# Altium schematic sheet pca20054_sheet5_connectors.SchDoc
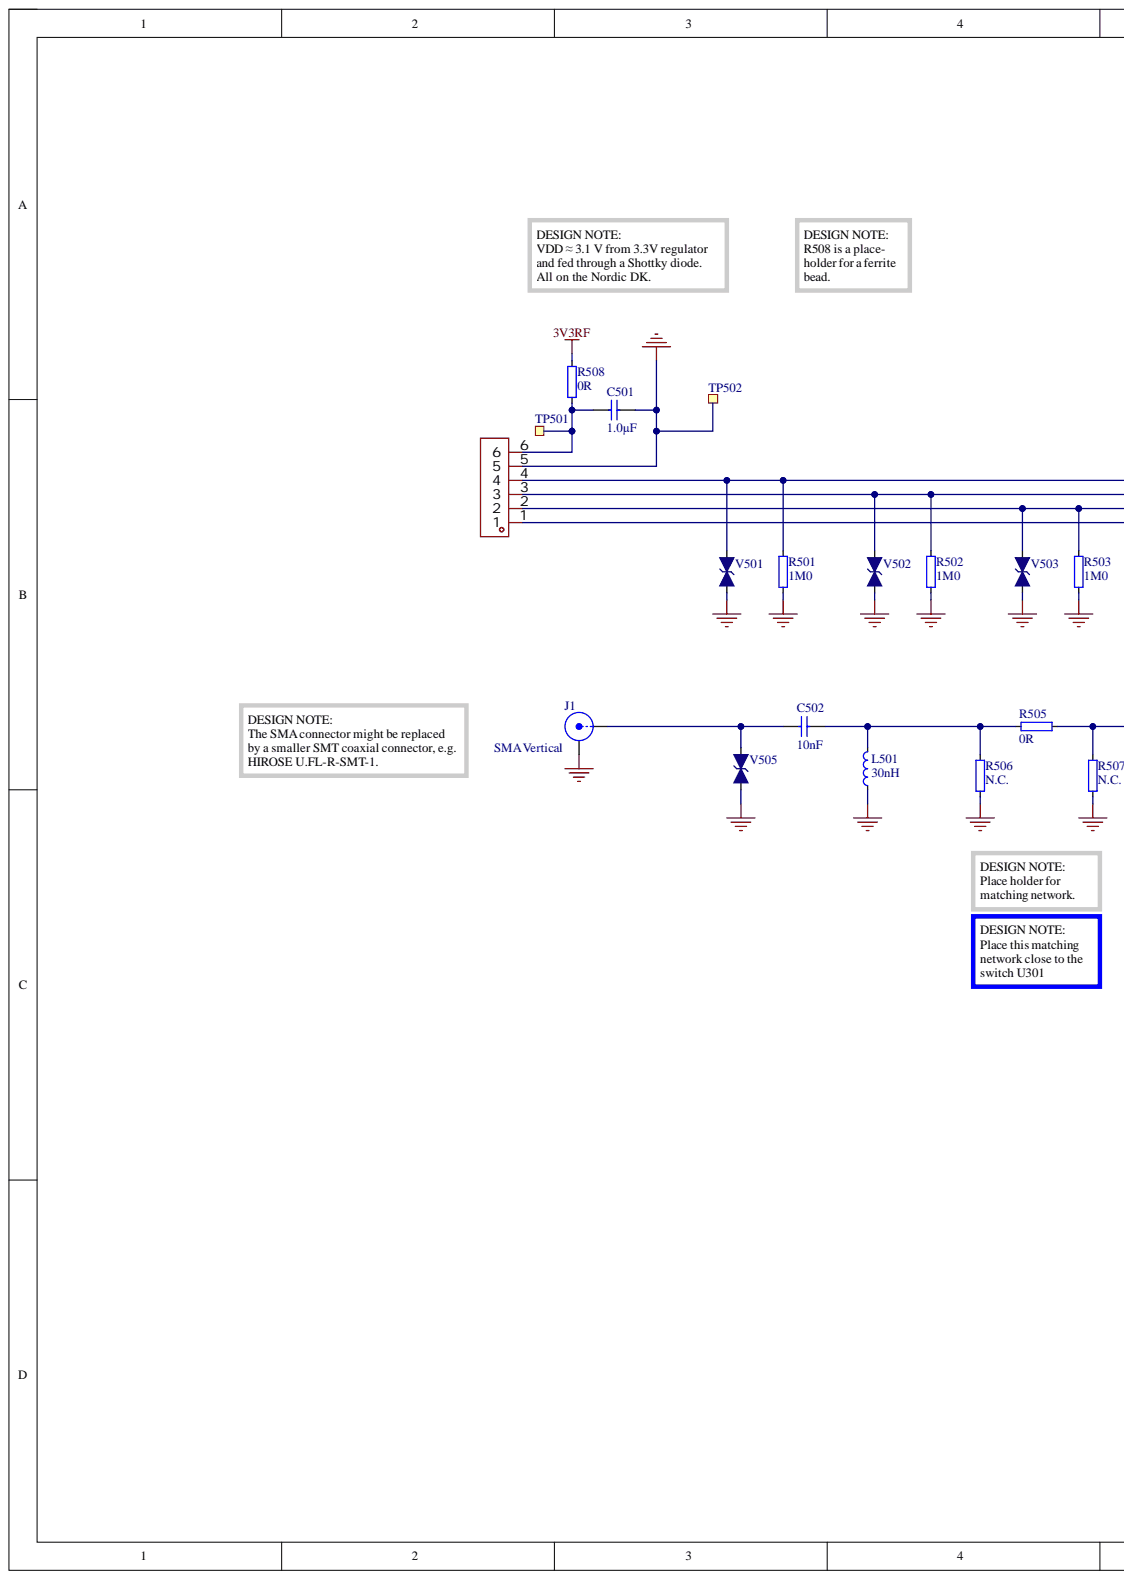
[diagram p0: pca20054_sheet5_connectors.SchDoc, left]
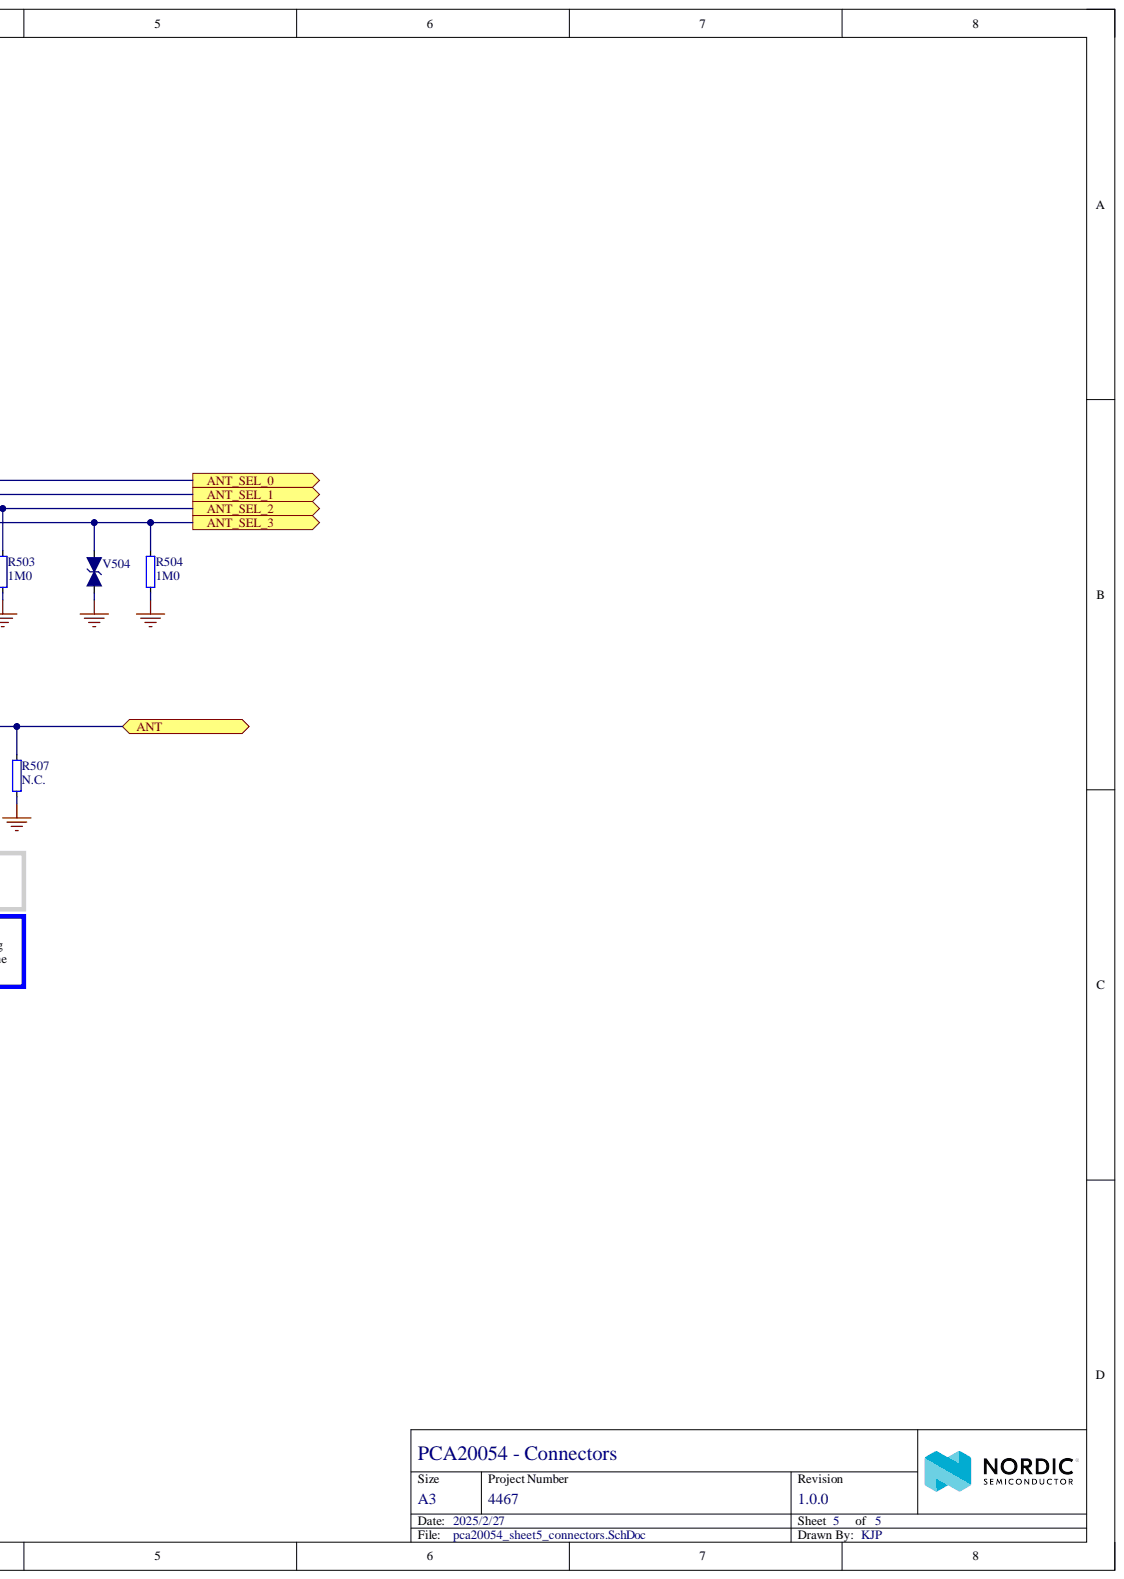
[diagram p1: pca20054_sheet5_connectors.SchDoc, right]

## Parts
C501  1.0µF  [CAPC1608X06L]
C502  10nF  [CAPC1005X04L]
J1  SMA Vertical  [CON_SMA_COAX_FEM_STRAIGHT_5P_1]
L501  30nH  [INDC1005X04L_WW]
R501  1M0  [RESC1005X04L]
R502  1M0  [RESC1005X04L]
R503  1M0  [RESC1005X04L]
R504  1M0  [RESC1005X04L]
R505  0R  [RESC1005X04L]
R506  N.C.  [RESC1005X04L_NC]
R507  N.C.  [RESC1005X04L_NC]
R508  0R  [RESC1005X04L]
TP501  Ø1.0mm,SMD  [TP_SMD_?.0mm]  (value not drawn)  (no BOM)
TP502  Ø1.0mm,SMD  [TP_SMD_?.0mm]  (value not drawn)  (no BOM)
U??  ZX-XH2.54-6PZZ  [CONN-TH_6P-P2.50_ZX-XH2.54-6PZZ]  (value not drawn)
V501  LXES15AAA1-133  [RESC1005X04L]  (value not drawn)
V502  LXES15AAA1-133  [RESC1005X04L]  (value not drawn)
V503  LXES15AAA1-133  [RESC1005X04L]  (value not drawn)
V504  LXES15AAA1-133  [RESC1005X04L]  (value not drawn)
V505  LXES15AAA1-133  [RESC1005X04L]  (value not drawn)

## Ports
ANT, ANT_SEL_0, ANT_SEL_1, ANT_SEL_2, ANT_SEL_3

## Nets
3V3RF: R508.2
GND: C501.1 J1.2 L501.1 R501.1 R502.1 R503.1 R504.1 R506.1 R507.1 TP502.1 U??.5 V501.2 V502.2 V503.2 V504.2 V505.2
ANT: R505.2 R507.2 ; port ANT
ANT_SEL_0: R501.2 U??.4 V501.1 ; port ANT_SEL_0
ANT_SEL_1: R502.2 U??.3 V502.1 ; port ANT_SEL_1
ANT_SEL_2: R503.2 U??.2 V503.1 ; port ANT_SEL_2
ANT_SEL_3: R504.2 U??.1 V504.1 ; port ANT_SEL_3
NetC501_2: C501.2 R508.1 TP501.1 U??.6
NetC502_1: C502.1 L501.2 R505.1 R506.2
NetC502_2: C502.2 J1.1 V505.1

## Library data of the parts
C501 = C0603-1µ0: Capacitor, X7R, ±10%; Part number IPS: 00000000616; Artikkelnr Noca: 20039282; Database Table Name: Capacitors$; Note: 16V; Component Kind: Standard; ComponentLink1Description: Datasheet; ComponentLink2Description: Supplier link; Footprint Doc: 0603; LatestRevisionDate: 2013-06-05; Library Name: Nordic Passive DB.DbLib; Part Number: C0603-1µ0; Pin Count: 2; Published: 2009-05-08; Value: 1.0µF; Publisher: Nordic Semiconductor
C502 = C0402-10n: Capacitor, X7R, ±10%; Part number IPS: 00000007784; Artikkelnr Noca: 20039166; Database Table Name: Capacitors$; Note: 16V; Component Kind: Standard; ComponentLink1Description: Datasheet; ComponentLink2Description: Supplier link; Footprint Doc: 0402; LatestRevisionDate: 2009-11-23; Library Name: Nordic Passive DB.DbLib; Part Number: C0402-10n; Pin Count: 2; Published: 2009-05-08; Value: 10nF; Publisher: Nordic Semiconductor
J1 = SMA-Vert-Std_Sigma: SMA Vertical connector; Artikkelnr Noca: N.A.; Database Table Name: Connectors$; Component Kind: Standard; ComponentLink1Description: Datasheet; ComponentLink2Description: Supplier link; Footprint Doc: SMA-VERT; LatestRevisionDate: 2009-12-12; Library Name: Nordic Passive DB.DbLib; Note: SMA vertical; Part Number: SMA-Vert-Std_Sigma; Part number IPS: N.A.; Pin Count: 5; Published: 2009-12-12; Value: SMA Vertical; Publisher: Nordic Semiconductor
L501 = L0402-30n-Wirewound-Murata: Inductor, 270mA, ±2%, 580mΩ, Q=25; Manufacturer: Murata; Manufacturer Part Number: LQW15AN30NG00; Artikkelnr Noca: N.A.; Database Table Name: Inductors$; Note: -55°C ~ 125°C; Component Kind: Standard; ComponentLink1Description: Datasheet; ComponentLink1URL: https://search.murata.co.jp/Ceramy/image/img/P02/JELF243A-0050.pdf; ComponentLink2Description: Supplier link; Footprint Doc: 0402; HelpURL: https://psearch.en.murata.com/inductor/product/LQW15AN30NG00%23.html; LatestRevisionDate: 2019-04-16; Library Name: Nordic Passive DB.DbLib; Part Number: L0402-30n-Wirewound-Murata; Part number IPS: N.A.; Pin Count: 2; Published: 2019-04-16; Supplier 1: Digi-Key; Supplier Part Number 1: 490-6802-1-ND; Value: 30nH; Publisher: Nordic Semiconductor
R501-R504 = R0402-1M0: Resistor, ±1%, 0.063W; Part number IPS: 00000006807; Artikkelnr Noca: 27049145; Database Table Name: Resistors$; Note: Chip Res 1%; Component Kind: Standard; ComponentLink1Description: Datasheet; ComponentLink2Description: Supplier link; Footprint Doc: 0402; LatestRevisionDate: 2009-11-23; Library Name: Nordic Passive DB.DbLib; Part Number: R0402-1M0; Pin Count: 2; Published: 2009-05-08; Value: 1M0; Publisher: Nordic Semiconductor
R505 R508 = R0402-0R: Resistor, ±5%, 0.063W; Part number IPS: 00000007128; Artikkelnr Noca: 27049000; Database Table Name: Resistors$; Note: Chip Res 5%; Component Kind: Standard; ComponentLink1Description: Datasheet; ComponentLink2Description: Supplier link; Footprint Doc: 0402; LatestRevisionDate: 2009-11-23; Library Name: Nordic Passive DB.DbLib; Part Number: R0402-0R; Pin Count: 2; Published: 2009-05-08; Supplier 1: Farnell; Supplier Part Number 1: 146-9661; Value: 0R; Publisher: Nordic Semiconductor
R506 R507 = R0402-N.C.: Not mounted; Artikkelnr Noca: N.A.; Assembly: Not mounted; Database Table Name: Resistors$; Note: Chip Res; Component Kind: Standard; ComponentLink1Description: Datasheet; ComponentLink2Description: Supplier link; Footprint Doc: 0402; LatestRevisionDate: 2009-05-08; Library Name: Nordic Passive DB.DbLib; Part Number: R0402-N.C.; Part number IPS: N.A.; Pin Count: 2; Published: 2009-05-08; Value: N.C.; Publisher: Nordic Semiconductor
TP501 TP502 = TP_SMD_Ø1.0MM: 1.0mm circular SMD testpad; Manufacturer: Nordic Semiconductor; Artikkelnr Noca: N.A.; Database Table Name: Connectors$; ComponentLink1Description: Datasheet; ComponentLink2Description: Supplier link; Footprint Doc: TP_SMD_Ø1.0mm; LatestRevisionDate: 2013-06-11; Library Name: Nordic Passive DB.DbLib; Part Number: TP_SMD_Ø1.0MM; Part number IPS: N.A.; Pin Count: 1; Published: 2013-06-11; Value: Ø1.0mm,SMD; Publisher: Nordic Semiconductor
U?? = ZX-XH2.54-6PZZ: Manufacturer: Megastar(兆星); Add into BOM: yes; Flame Retardant Rating: UL94V-0; Number of PINs Per Row: 6; Pins Structure: 1x6P; Pitch: 2.5mm; Plastic Material: PA66; Rated Current: 3A; Rated Voltage (Max): 250V; Reference Series: XH; X-Length of Bottom Edge on Board (Spacing Line): 17.5mm; Y-Width of Bottom Edge on Board: 5.9mm; Z-Height of the Board: 7mm; Color: 白色; Component Kind: Standard; Contact Material: 黄铜; Contact Plating: 锡; Convert to PCB: yes; Datasheet: https://atta.szlcsc.com/upload/public/pdf/source/20230619/1243AD0A516E39CD3D274D8985780568.pdf; Device: ZX-XH2.54-6PZZ; JLCPCB Part Class: Extended Part; LCSC Part Name: 1x6P 间距:2.5mm 直插 系列:XH; Library Name: ZX-XH2.54-6PZZ1_ZX-XH2.54-6PZZ.SCHLIB; Manufacturer Part: ZX-XH2.54-6PZZ; Mounting Type: 直插; Number of Pins: 6P; Number of Rows: 1; Operating Temperature: -25℃~+85℃; PCB3D: CONN-TH_6P-P2.50_ZX-XH2.54-6PZZ; Row Spacing: -; Supplementary Features: -; Supplier: LCSC; Supplier Footprint: 插件,P=2.5mm; Supplier Part: C7429636; Symbol: ZX-XH2.54-6PZZ
V501-V505 = LXES15AAA1-133: TVS DIODE 15VWM 0402; Manufacturer: Murata; Artikkelnr Noca: N.A.; Database Table Name: Semiconductors$; Component Kind: Standard; ComponentLink1Description: Datasheet; ComponentLink2Description: Supplier link; Footprint Doc: 0402; HelpURL: http://www.murata.com/en-us/products/productdata/8796832759838/CRESD-E.pdf; LatestRevisionDate: 2017-09-20; Library Name: Nordic Discrete DB.DbLib; Manufacturer Part Number: LXES15AAA1-133; Part Number: LXES15AAA1-133; Part number IPS: N.A.; Pin Count: 2; Published: 2017-09-20; Supplier 1: Digi-Key; Supplier 2: Mouser; Supplier Part Number 1: 490-13209-6-ND; Supplier Part Number 2: 81-LXES15AAA1-133; Value: LXES15AAA1-133; Publisher: Nordic Semiconductor
